# Altium schematic sheet FlightControl.SchDoc
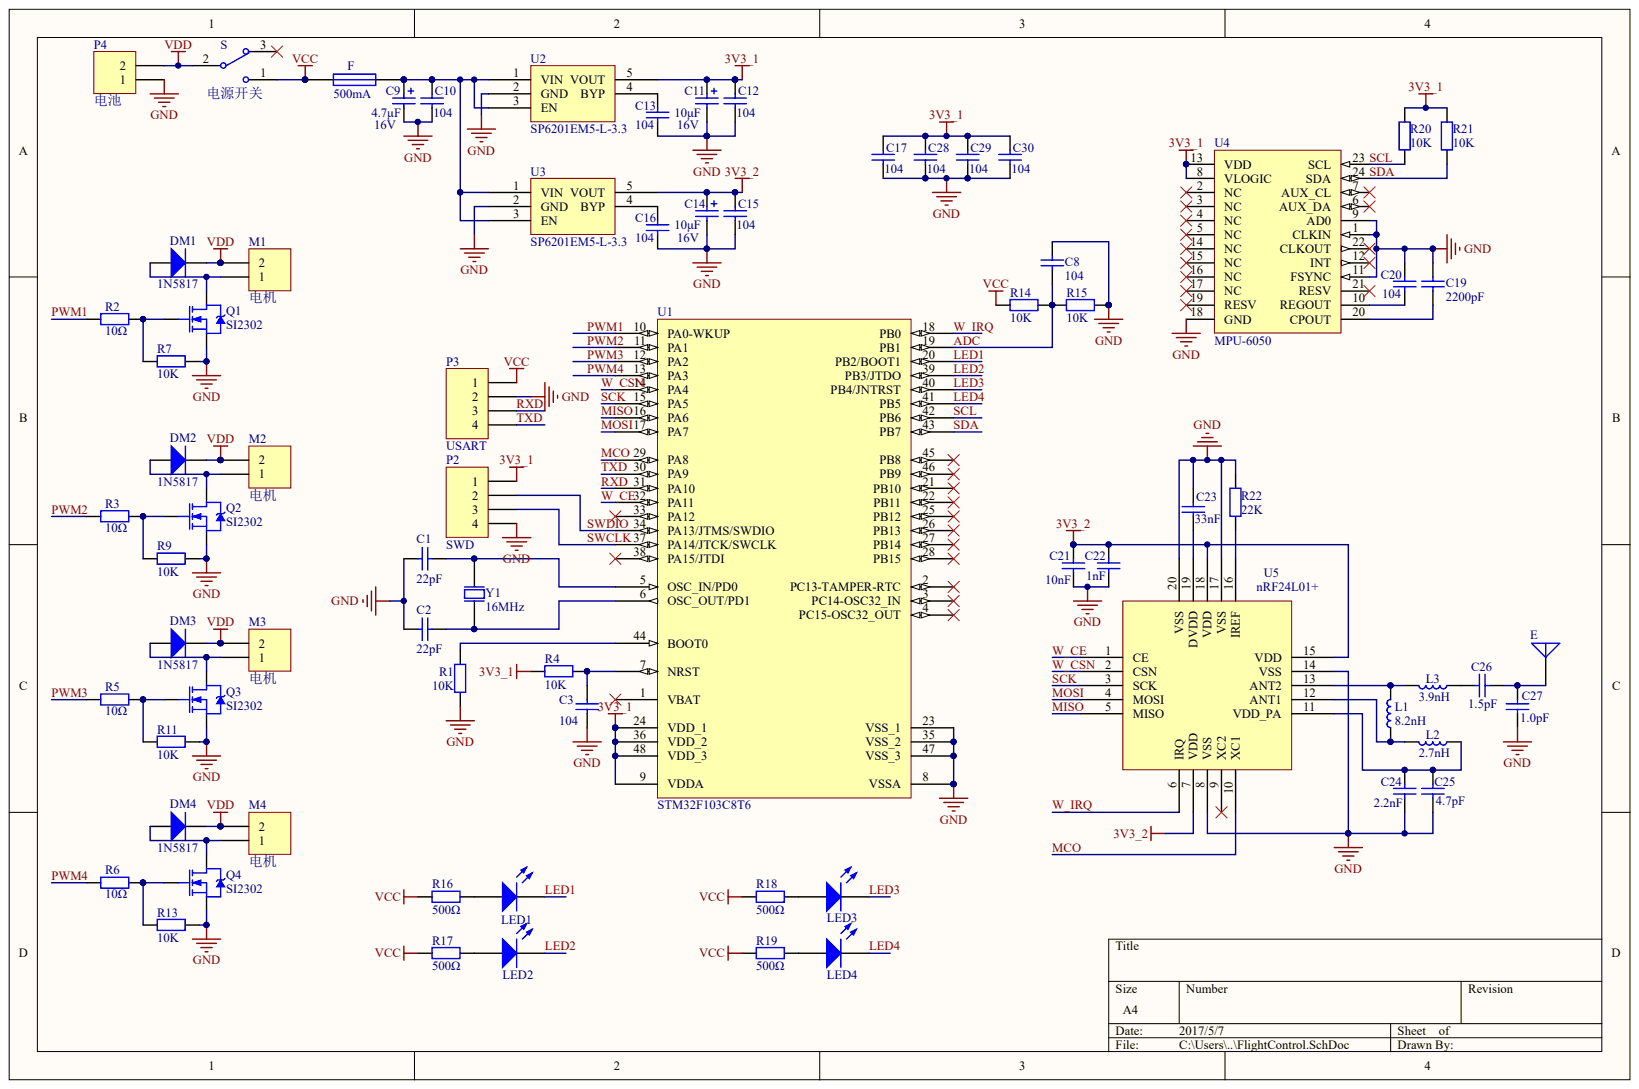
[diagram p0: FlightControl.SchDoc]

## Parts
C1  22pF
C2  22pF
C3  104
C8  104
C9  4.7μF  Voltage: 16V
C10  104
C11  10μF  Voltage: 16V
C12  104
C13  104
C14  10μF  Voltage: 16V
C15  104
C16  104
C17  104
C19  2200pF
C20  104
C21  10nF
C22  1nF
C23  33nF
C24  2.2nF
C25  4.7pF
C26  1.5pF
C27  1.0pF
C28  104
C29  104
C30  104
DM1  1N5817
DM2  1N5817
DM3  1N5817
DM4  1N5817
E  (no value)
F  500mA
L1  8.2nH
L2  2.7nH
L3  3.9nH
LED1  LED Chip  (value not drawn)
LED2  LED Chip  (value not drawn)
LED3  LED Chip  (value not drawn)
LED4  LED Chip  (value not drawn)
M1  电机
M2  电机
M3  电机
M4  电机
P2  SWD
P3  USART
P4  电池
Q1  SI2302
Q2  SI2302
Q3  SI2302
Q4  SI2302
R1  10K
R2  10Ω
R3  10Ω
R4  10K
R5  10Ω
R6  10Ω
R7  10K
R9  10K
R11  10K
R13  10K
R14  10K
R15  10K
R16  500Ω
R17  500Ω
R18  500Ω
R19  500Ω
R20  10K
R21  10K
R22  22K
S  电源开关
U1  STM32F103C8T6
U2  SP6201EM5-L-3.3
U3  SP6201EM5-L-3.3
U4  MPU-6050
U5  nRF24L01+
Y1  Frequency: 16MHz  (hidden comment: 8MHz)

## Nets
3V3_1: C11.2 C12.2 C17.2 C28.2 C29.2 C30.2 P2.1 R4.1 R20.2 R21.2 U1.9(VDDA) U1.24(VDD_1) U1.36(VDD_2) U1.48(VDD_3) U2.5(VOUT) U4.8(VLOGIC) U4.13(VDD)
3V3_2: C14.2 C15.2 C21.1 C22.1 U3.5(VOUT) U5.7(VDD) U5.15(VDD) U5.18(VDD)
GND: C1.1 C2.1 C3.1 C8.1 C9.1 C10.1 C11.1 C12.1 C13.1 C14.1 C15.1 C16.1 C17.1 C19.1 C20.1 C21.2 C22.2 C23.1 C24.2 C25.2 C27.1 C28.1 C29.1 C30.1 P2.4 P3.2 P4.1 Q1.3 Q2.3 Q3.3 Q4.3 R1.1 R7.2 R9.2 R11.2 R13.2 R15.2 R22.2 U1.8(VSSA) U1.23(VSS_1) U1.35(VSS_2) U1.47(VSS_3) U2.2(GND) U3.2(GND) U4.1(CLKIN) U4.9(AD0) U4.11(FSYNC) U4.18(GND) U5.8(VSS) U5.14(VSS) U5.17(VSS) U5.20(VSS)
VCC: F.1 P3.1 R14.1 R16.1 R17.1 R18.1 R19.1 S.1
VDD: DM1.2 DM2.2 DM3.2 DM4.2 M1.2 M2.2 M3.2 M4.2 P4.2 S.2
ADC: C8.2 R14.2 R15.1 U1.19(PB1)
LED1: LED1.2 U1.20(PB2/BOOT1)
LED2: LED2.2 U1.39(PB3/JTDO)
LED3: LED3.2 U1.40(PB4/JNTRST)
LED4: LED4.2 U1.41(PB5)
MCO: U1.29(PA8) U5.10(XC1)
MISO: U1.16(PA6) U5.5(MISO)
MOSI: U1.17(PA7) U5.4(MOSI)
PWM1: R2.1 U1.10(PA0-WKUP)
PWM2: R3.1 U1.11(PA1)
PWM3: R5.1 U1.12(PA2)
PWM4: R6.1 U1.13(PA3)
RXD: P3.3 U1.31(PA10)
SCK: U1.15(PA5) U5.3(SCK)
SCL: R20.1 U1.42(PB6) U4.23(SCL)
SDA: R21.1 U1.43(PB7) U4.24(SDA)
SWCLK: P2.3 U1.37(PA14/JTCK/SWCLK)
SWDIO: P2.2 U1.34(PA13/JTMS/SWDIO)
TXD: P3.4 U1.30(PA9)
W_CE: U1.32(PA11) U5.1(CE)
W_CSN: U1.14(PA4) U5.2(CSN)
W_IRQ: U1.18(PB0) U5.6(IRQ)
NetC1_2: C1.2 U1.5(OSC_IN/PD0) Y1.2
NetC2_2: C2.2 U1.6(OSC_OUT/PD1) Y1.1
NetC3_2: C3.2 R4.2 U1.7(NRST)
NetC9_2: C9.2 C10.2 F.2 U2.1(VIN) U2.3(EN) U3.1(VIN) U3.3(EN)
NetC13_2: C13.2 U2.4(BYP)
NetC16_2: C16.2 U3.4(BYP)
NetC19_2: C19.2 U4.20(CPOUT)
NetC20_2: C20.2 U4.10(REGOUT)
NetC23_2: C23.2 U5.19(DVDD)
NetC24_1: C24.1 C25.1 L2.1 U5.11(VDD_PA)
NetC26_1: C26.1 L3.1
NetC26_2: C26.2 C27.2 E.1
NetDM1_1: DM1.1 M1.1 Q1.2
NetDM2_1: DM2.1 M2.1 Q2.2
NetDM3_1: DM3.1 M3.1 Q3.2
NetDM4_1: DM4.1 M4.1 Q4.2
NetL1_1: L1.1 L2.2 U5.12(ANT1)
NetL1_2: L1.2 L3.2 U5.13(ANT2)
NetLED1_1: LED1.1 R16.2
NetLED2_1: LED2.1 R17.2
NetLED3_1: LED3.1 R18.2
NetLED4_1: LED4.1 R19.2
NetQ1_1: Q1.1 R2.2 R7.1
NetQ2_1: Q2.1 R3.2 R9.1
NetQ3_1: Q3.1 R5.2 R11.1
NetQ4_1: Q4.1 R6.2 R13.1
NetR1_2: R1.2 U1.44(BOOT0)
NetR22_1: R22.1 U5.16(IREF)

Not connected: S 3; U1 1(VBAT) 2(PC13-TAMPER-RTC) 3(PC14-OSC32_IN) 4(PC15-OSC32_OUT) 21(PB10) 22(PB11) 25(PB12) 26(PB13) 27(PB14) 28(PB15) 33(PA12) 38(PA15/JTDI) 45(PB8) 46(PB9); U4 2(NC) 3(NC) 4(NC) 5(NC) 6(AUX_DA) 7(AUX_CL) 12(INT) 14(NC) 15(NC) 16(NC) 17(NC) 19(RESV) 21(RESV) 22(CLKOUT); U5 9(XC2)

## Library data of the parts
L1-L3 = (no symbol name): Code_IEC: 1005; Published: 8-Jun-2000; LatestRevisionDate: 29-May-2009; LatestRevisionNote: IPC-7351 Footprint Added.; PackageReference: 0402-A; PackageDescription: Chip Inductor; Code_JEITA: 0402; Publisher: Altium Limited
  L1: Value: 8.2nH
  L2: Value: 2.7nH
  L3: Value: 3.9nH
Q1-Q4 = (no symbol name): Note: Pin 2 is electrically connected to pin 4.; Published: 8-Jun-2000; LatestRevisionDate: 17-Jul-2002; LatestRevisionNote: Re-released for DXP Platform.; DatasheetVersion: PD-9.814; PackageVersion: Feb-1997; PackageReference: TO-220AB; PackageDescription: TO, Thru-Hole, Vertical, Heatsink Mounted; 3 In-Line Leads; Pitch 2.54 mm; ComponentLink1Description: Manufacturer Link; ComponentLink1URL: http://www.irf.com/; Publisher: Altium Limited
C1-C3 C8-C17 C19-C30 DM1-DM4 E F LED1-LED4 M1-M4 P2-P4 R1-R7 R9 R11 R13-R22 S U1-U5 Y1 = (no symbol name)
  C1 C2: Published: 8-Jun-2000; LatestRevisionDate: 17-Jul-2002; LatestRevisionNote: Re-released for DXP Platform.; PackageReference: RAD-0.3; PackageDescription: Radial Cap, Thru-Hole; 2 Leads; 0.3 in Pin Spacing; Value: 22pF
  C3 C8 C10 C12 C13 C15-C17 C20 C28-C30: Published: 8-Jun-2000; LatestRevisionDate: 17-Jul-2002; LatestRevisionNote: Re-released for DXP Platform.; PackageReference: RAD-0.3; PackageDescription: Radial Cap, Thru-Hole; 2 Leads; 0.3 in Pin Spacing; Value: 104
  C9: Published: 8-Jun-2000; Revision: July-2002: Re-released for DXP Platform.; Value: 4.7μF
  C11 C14: Published: 8-Jun-2000; Revision: July-2002: Re-released for DXP Platform.; Value: 10μF
  C19: Published: 8-Jun-2000; LatestRevisionDate: 17-Jul-2002; LatestRevisionNote: Re-released for DXP Platform.; PackageReference: RAD-0.3; PackageDescription: Radial Cap, Thru-Hole; 2 Leads; 0.3 in Pin Spacing; Value: 2200pF
  C21: Published: 8-Jun-2000; LatestRevisionDate: 17-Jul-2002; LatestRevisionNote: Re-released for DXP Platform.; PackageReference: RAD-0.3; PackageDescription: Radial Cap, Thru-Hole; 2 Leads; 0.3 in Pin Spacing; Value: 10nF
  C22: Published: 8-Jun-2000; LatestRevisionDate: 17-Jul-2002; LatestRevisionNote: Re-released for DXP Platform.; PackageReference: RAD-0.3; PackageDescription: Radial Cap, Thru-Hole; 2 Leads; 0.3 in Pin Spacing; Value: 1nF
  C23: Published: 8-Jun-2000; LatestRevisionDate: 17-Jul-2002; LatestRevisionNote: Re-released for DXP Platform.; PackageReference: RAD-0.3; PackageDescription: Radial Cap, Thru-Hole; 2 Leads; 0.3 in Pin Spacing; Value: 33nF
  C24: Published: 8-Jun-2000; LatestRevisionDate: 17-Jul-2002; LatestRevisionNote: Re-released for DXP Platform.; PackageReference: RAD-0.3; PackageDescription: Radial Cap, Thru-Hole; 2 Leads; 0.3 in Pin Spacing; Value: 2.2nF
  C25: Published: 8-Jun-2000; LatestRevisionDate: 17-Jul-2002; LatestRevisionNote: Re-released for DXP Platform.; PackageReference: RAD-0.3; PackageDescription: Radial Cap, Thru-Hole; 2 Leads; 0.3 in Pin Spacing; Value: 4.7pF
  C26: Published: 8-Jun-2000; LatestRevisionDate: 17-Jul-2002; LatestRevisionNote: Re-released for DXP Platform.; PackageReference: RAD-0.3; PackageDescription: Radial Cap, Thru-Hole; 2 Leads; 0.3 in Pin Spacing; Value: 1.5pF
  C27: Published: 8-Jun-2000; LatestRevisionDate: 17-Jul-2002; LatestRevisionNote: Re-released for DXP Platform.; PackageReference: RAD-0.3; PackageDescription: Radial Cap, Thru-Hole; 2 Leads; 0.3 in Pin Spacing; Value: 1.0pF
  E: Published: 24-Mar-1999; LatestRevisionDate: 17-Jul-2002; LatestRevisionNote: Re-released for DXP Platform.; PackageReference: PIN1
  F LED1-LED4 Y1: Published: 8-Jun-2000; Revision: July-2002: Re-released for DXP Platform.
  M1-M4 P2-P4: LatestRevisionDate: 17-Jul-2002; LatestRevisionNote: Re-released for DXP Platform.
  R1 R4 R7 R9 R11 R13-R15 R20 R21: Published: 8-Jun-2000; Revision: July-2002: Re-released for DXP Platform.; Value: 10K
  R2 R3 R5 R6: Published: 8-Jun-2000; Revision: July-2002: Re-released for DXP Platform.; Value: 10Ω
  R16-R19: Published: 8-Jun-2000; Revision: July-2002: Re-released for DXP Platform.; Value: 500Ω
  R22: Published: 8-Jun-2000; Revision: July-2002: Re-released for DXP Platform.; Value: 22K
  S: Published: 24-Mar-1999; LatestRevisionDate: 17-Dec-2003; LatestRevisionNote: Schematic revised, PCB Footprint replaced.; DatasheetVersion: 05-Dec-2000; PackageReference: TL36WW15050; PackageDescription: Switch; 4 Leads; ComponentLink1Description: Manufacturer Link; ComponentLink1URL: http://www.apem.com
  U1: Published: *; DatasheetVersion: *; PackageVersion: *; PackageReference: *; PackageDescription: *; ComponentLink1Description: *; ComponentLink1URL: *; ComponentLink2Description: *; ComponentLink2URL: *; Publisher: *
  C1-C3 C8-C17 C19-C30 E F LED1-LED4 M1-M4 P2-P4 R1-R7 R9 R11 R13-R22 S Y1: Publisher: Altium Limited
